# Altium schematic sheet [4]pico.SchDoc
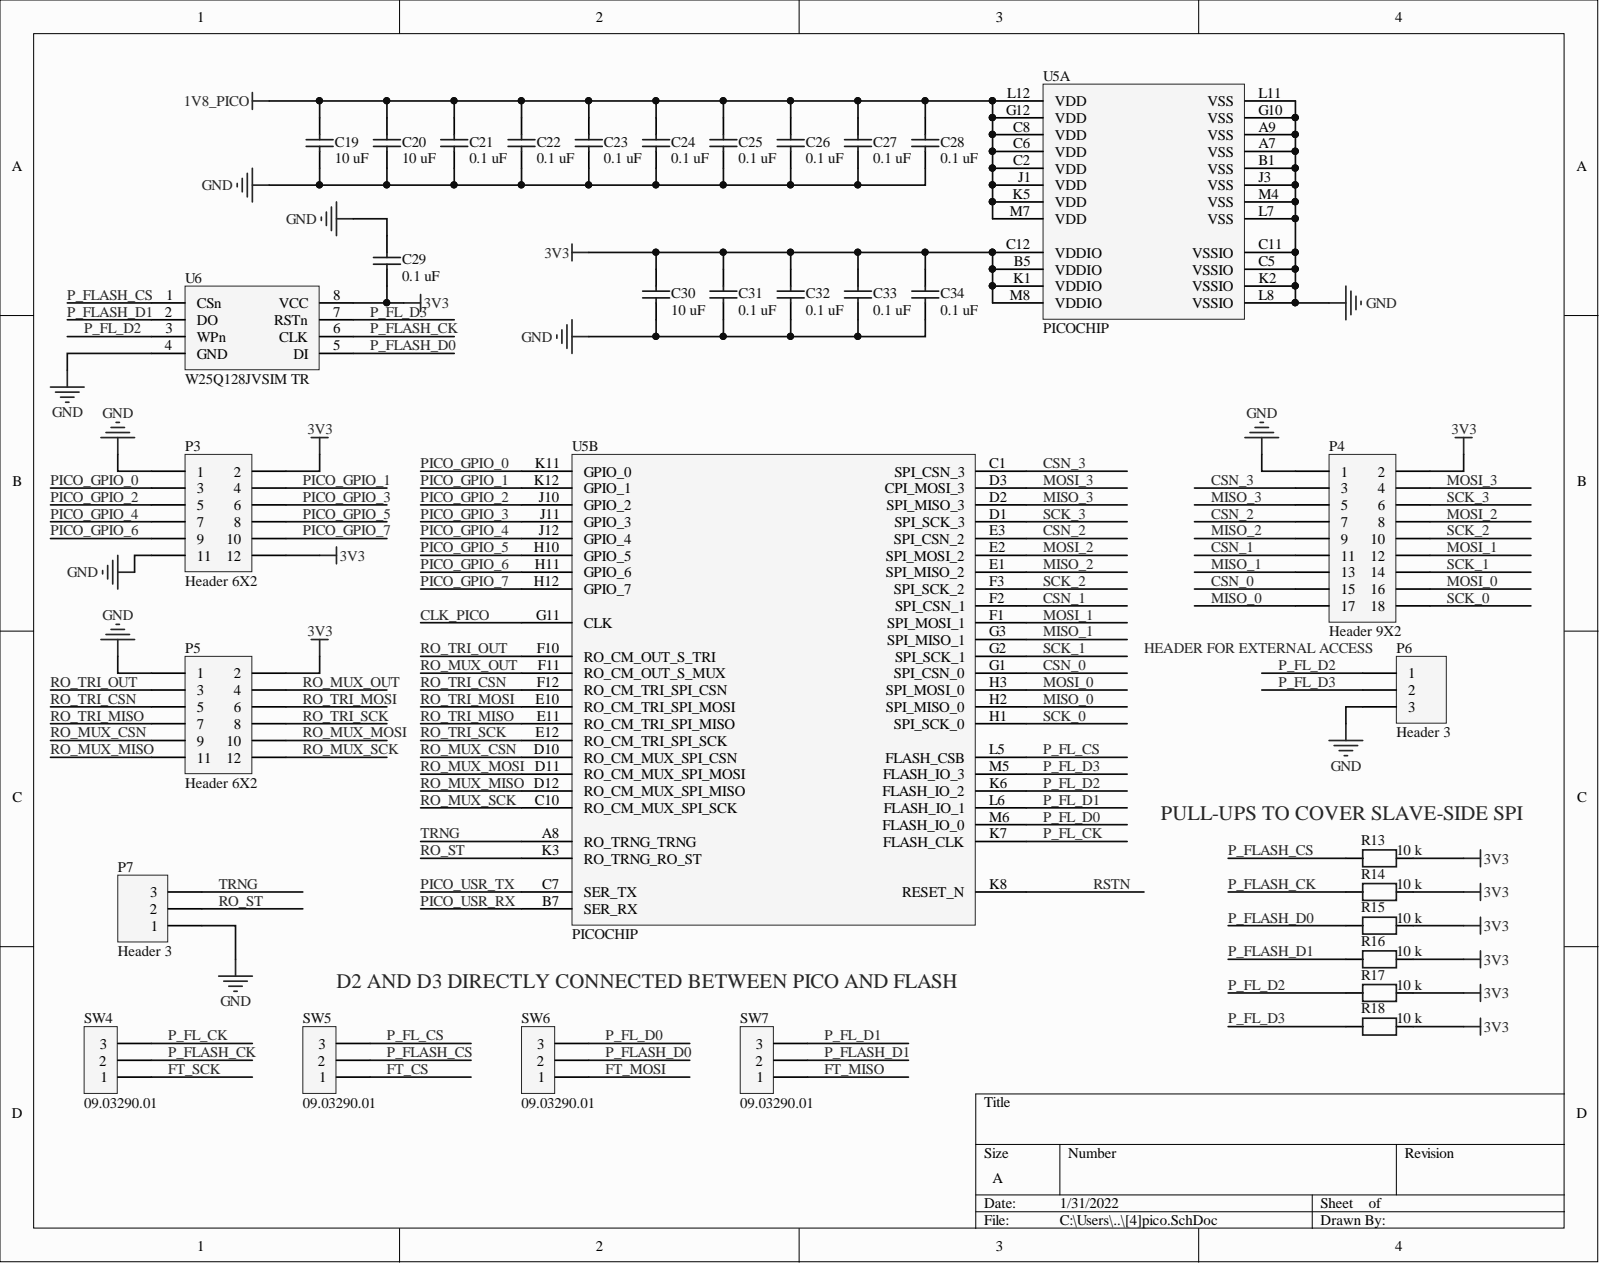
[diagram p0: [4]pico.SchDoc]

## Parts
C19  value 10 uF  [0603-CAP-ASM]  (hidden comment: Cap)
C20  value 10 uF  [0603-CAP-ASM]  (hidden comment: Cap)
C21  value 0.1 uF  [0402-CAP-ASM]  (hidden comment: Cap)
C22  value 0.1 uF  [0402-CAP-ASM]  (hidden comment: Cap)
C23  value 0.1 uF  [0402-CAP-ASM]  (hidden comment: Cap)
C24  value 0.1 uF  [0402-CAP-ASM]  (hidden comment: Cap)
C25  value 0.1 uF  [0402-CAP-ASM]  (hidden comment: Cap)
C26  value 0.1 uF  [0402-CAP-ASM]  (hidden comment: Cap)
C27  value 0.1 uF  [0402-CAP-ASM]  (hidden comment: Cap)
C28  value 0.1 uF  [0402-CAP-ASM]  (hidden comment: Cap)
C29  value 0.1 uF  [0402-CAP-ASM]  (hidden comment: Cap)
C30  value 10 uF  [0603-CAP-ASM]  (hidden comment: Cap)
C31  value 0.1 uF  [0402-CAP-ASM]  (hidden comment: Cap)
C32  value 0.1 uF  [0402-CAP-ASM]  (hidden comment: Cap)
C33  value 0.1 uF  [0402-CAP-ASM]  (hidden comment: Cap)
C34  value 0.1 uF  [0402-CAP-ASM]  (hidden comment: Cap)
P3  Header 6X2  [HDR_2x6_2R54_V_M]
P4  Header 9X2  [HDR_2x9_2R54_V_M]
P5  Header 6X2  [HDR_2x6_2R54_V_M]
P6  Header 3  [Header 1x3 male]
P7  Header 3  [Header 1x3 male]
R13  value 10 k  [0402-RES-ASM]  (hidden comment: res)
R14  value 10 k  [0402-RES-ASM]  (hidden comment: res)
R15  value 10 k  [0402-RES-ASM]  (hidden comment: res)
R16  value 10 k  [0402-RES-ASM]  (hidden comment: res)
R17  value 10 k  [0402-RES-ASM]  (hidden comment: res)
R18  value 10 k  [0402-RES-ASM]  (hidden comment: res)
SW4  09.03290.01  [09.03290.01]
SW5  09.03290.01  [09.03290.01]
SW6  09.03290.01  [09.03290.01]
SW7  09.03290.01  [09.03290.01]
U5  PICOCHIP  [pga13x13]  (parts A,B)
U6  W25Q128JVSIM TR  [SO-8 208MIL]

## Nets
1V8_PICO: C19.2 C20.2 C21.2 C22.2 C23.2 C24.2 C25.2 C26.2 C27.2 C28.2 U5.C2(VDD) U5.C6(VDD) U5.C8(VDD) U5.G12(VDD) U5.J1(VDD) U5.K5(VDD) U5.L12(VDD) U5.M7(VDD)
3V3: C29.1 C30.2 C31.2 C32.2 C33.2 C34.2 P3.2 P3.12 P4.2 P5.2 R13.2 R14.2 R15.2 R16.2 R17.2 R18.2 U5.B5(VDDIO) U5.C12(VDDIO) U5.K1(VDDIO) U5.M8(VDDIO) U6.8(VCC)
GND: C19.1 C20.1 C21.1 C22.1 C23.1 C24.1 C25.1 C26.1 C27.1 C28.1 C29.2 C30.1 C31.1 C32.1 C33.1 C34.1 P3.1 P3.11 P4.1 P5.1 P6.3 P7.1 U5.A7(VSS) U5.A9(VSS) U5.B1(VSS) U5.C5(VSSIO) U5.C11(VSSIO) U5.G10(VSS) U5.J3(VSS) U5.K2(VSSIO) U5.L7(VSS) U5.L8(VSSIO) U5.L11(VSS) U5.M4(VSS) U6.4(GND)
CLK_PICO: U5.G11(CLK)
CSN_0: P4.15 U5.G1(SPI_CSN_0)
CSN_1: P4.11 U5.F2(SPI_CSN_1)
CSN_2: P4.7 U5.E3(SPI_CSN_2)
CSN_3: P4.3 U5.C1(SPI_CSN_3)
FT_CS: SW5.1
FT_MISO: SW7.1
FT_MOSI: SW6.1
FT_SCK: SW4.1
MISO_0: P4.17 U5.H2(SPI_MISO_0)
MISO_1: P4.13 U5.G3(SPI_MISO_1)
MISO_2: P4.9 U5.E1(SPI_MISO_2)
MISO_3: P4.5 U5.D2(SPI_MISO_3)
MOSI_0: P4.16 U5.H3(SPI_MOSI_0)
MOSI_1: P4.12 U5.F1(SPI_MOSI_1)
MOSI_2: P4.8 U5.E2(SPI_MOSI_2)
MOSI_3: P4.4 U5.D3(CPI_MOSI_3)
P_FL_CK: SW4.3 U5.K7(FLASH_CLK)
P_FL_CS: SW5.3 U5.L5(FLASH_CSB)
P_FL_D0: SW6.3 U5.M6(FLASH_IO_0)
P_FL_D1: SW7.3 U5.L6(FLASH_IO_1)
P_FL_D2: P6.1 R17.1 U5.K6(FLASH_IO_2) U6.3(WPn)
P_FL_D3: P6.2 R18.1 U5.M5(FLASH_IO_3) U6.7(RSTn)
P_FLASH_CK: R14.1 SW4.2 U6.6(CLK)
P_FLASH_CS: R13.1 SW5.2 U6.1(CSn)
P_FLASH_D0: R15.1 SW6.2 U6.5(DI)
P_FLASH_D1: R16.1 SW7.2 U6.2(DO)
PICO_GPIO_0: P3.3 U5.K11(GPIO_0)
PICO_GPIO_1: P3.4 U5.K12(GPIO_1)
PICO_GPIO_2: P3.5 U5.J10(GPIO_2)
PICO_GPIO_3: P3.6 U5.J11(GPIO_3)
PICO_GPIO_4: P3.7 U5.J12(GPIO_4)
PICO_GPIO_5: P3.8 U5.H10(GPIO_5)
PICO_GPIO_6: P3.9 U5.H11(GPIO_6)
PICO_GPIO_7: P3.10 U5.H12(GPIO_7)
PICO_USR_RX: U5.B7(SER_RX)
PICO_USR_TX: U5.C7(SER_TX)
RO_MUX_CSN: P5.9 U5.D10(RO_CM_MUX_SPI_CSN)
RO_MUX_MISO: P5.11 U5.D12(RO_CM_MUX_SPI_MISO)
RO_MUX_MOSI: P5.10 U5.D11(RO_CM_MUX_SPI_MOSI)
RO_MUX_OUT: P5.4 U5.F11(RO_CM_OUT_S_MUX)
RO_MUX_SCK: P5.12 U5.C10(RO_CM_MUX_SPI_SCK)
RO_ST: P7.2 U5.K3(RO_TRNG_RO_ST)
RO_TRI_CSN: P5.5 U5.F12(RO_CM_TRI_SPI_CSN)
RO_TRI_MISO: P5.7 U5.E11(RO_CM_TRI_SPI_MISO)
RO_TRI_MOSI: P5.6 U5.E10(RO_CM_TRI_SPI_MOSI)
RO_TRI_OUT: P5.3 U5.F10(RO_CM_OUT_S_TRI)
RO_TRI_SCK: P5.8 U5.E12(RO_CM_TRI_SPI_SCK)
RSTN: U5.K8(RESET_N)
SCK_0: P4.18 U5.H1(SPI_SCK_0)
SCK_1: P4.14 U5.G2(SPI_SCK_1)
SCK_2: P4.10 U5.F3(SPI_SCK_2)
SCK_3: P4.6 U5.D1(SPI_SCK_3)
TRNG: P7.3 U5.A8(RO_TRNG_TRNG)

## Library data of the parts
C19 C20 C30 = Cap: CAP CER 10UF 10V X5R 0603; Component Kind: Standard; Library Name: Miscellaneous Devices.IntLib; Supplier Part Number: 1276-1192-1-ND
C21-C29 C31-C34 = Cap: CAP CER 0.1UF 10V X5R 0402; Component Kind: Standard; Library Name: Miscellaneous Devices.IntLib; Supplier Part Number: 587-1227-1-ND
P3 P5 = Header 6X2: CONN HEADER VERT 12POS 2.54MM; Component Kind: Standard; Library Name: Miscellaneous Connectors.IntLib; Supplier Part Number: SAM1028-06-ND
P4 = Header 9X2: CONN HEADER VERT 18POS 2.54MM; Component Kind: Standard; Library Name: Miscellaneous Connectors.IntLib; Supplier Part Number: SAM9165-ND
P6 P7 = Header 3: CONN HEADER VERT 3POS 2.54MM; Component Kind: Standard; Library Name: Miscellaneous Connectors.IntLib; Supplier Part Number: SAM1031-03-ND
R13-R18 = RESISTOR: RES SMD 10K OHM 5% 1/16W 0402; Component Kind: Standard; Library Name: Mylib..SchLib; Supplier Part Number: 311-10KJRCT-ND
SW4-SW7 = 09.03290.01: 1K2 SW CHANGE OVER RAISED ACT; Component Kind: Standard; Library Name: Mylib..SchLib; Supplier Part Number: 1948-1087-ND
U5 = PICO: Component Kind: Standard; Description: PICOCHIP; Library Name: Mylib..SchLib
U6 = W25Q128JVSIM TR: IC FLASH 128M SPI 133MHZ 8SOIC; Component Kind: Standard; Library Name: Mylib..SchLib; Supplier Part Number: W25Q128JVSIMCT-ND
